# Altium schematic sheet Digital.SchDoc(Digital)
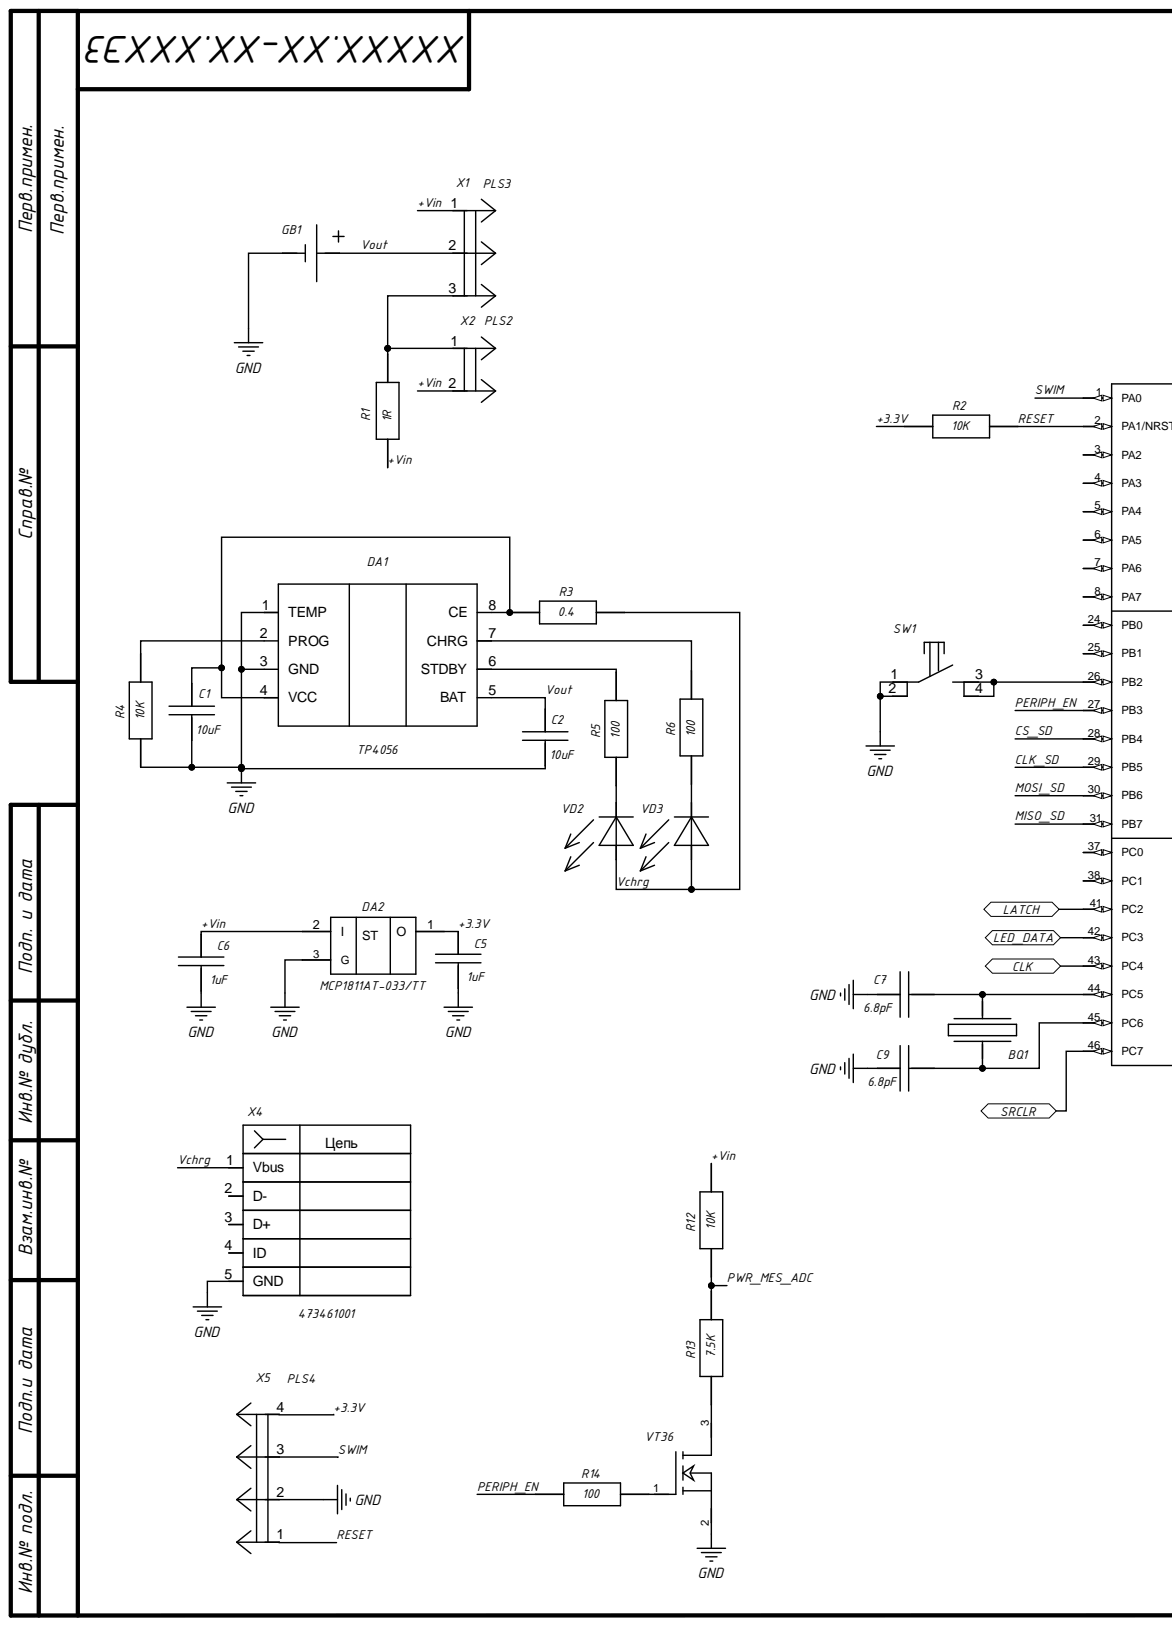
[diagram p0: Digital.SchDoc, left]
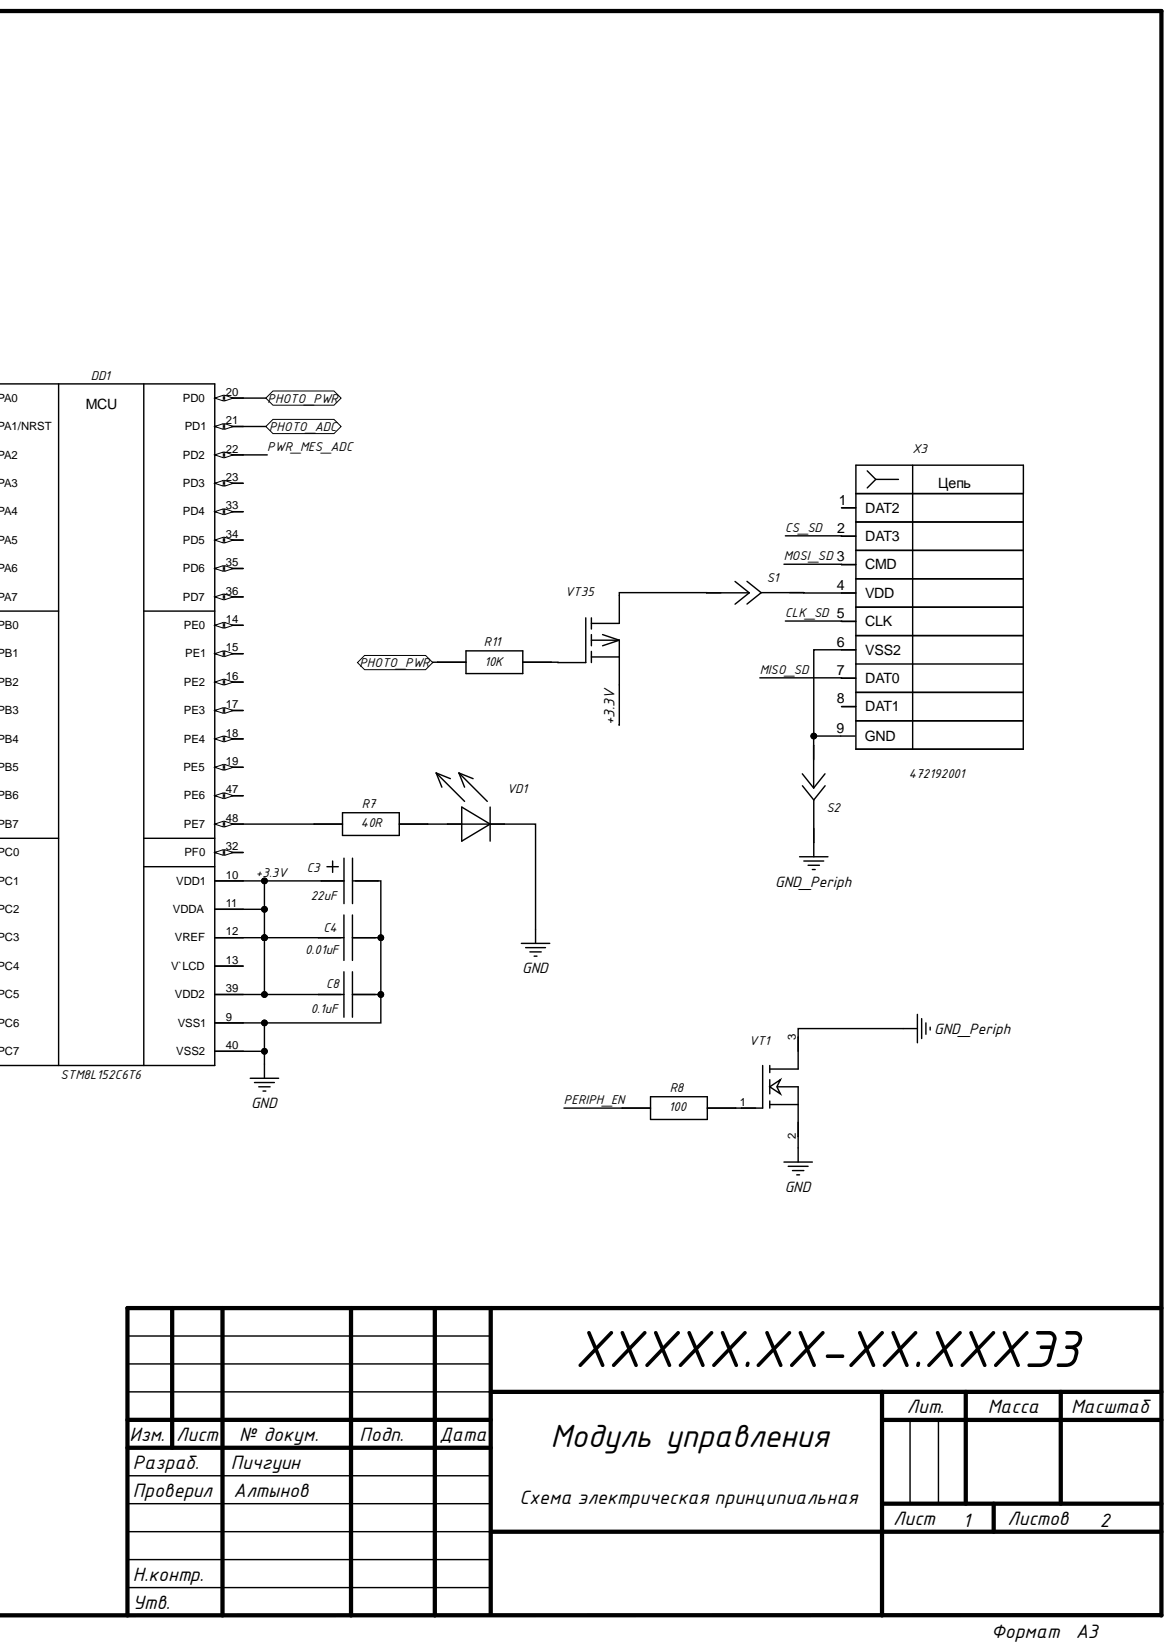
[diagram p1: Digital.SchDoc, right]

## Parts
BQ1  CM315D32768HZFT  [3215SMD]  (value not drawn)
C1  value 10uF  [SMD0603]  (hidden comment: C0603)
C2  value 10uF  [SMD0603]  (hidden comment: C0603)
C3  value 22uF  [AL_5X5.3]  (hidden comment: AL_5X5.3)
C4  value 0.01uF  [SMD0603]  (hidden comment: C0603)
C5  value 1uF  [SMD0603]  (hidden comment: C0603)
C6  value 1uF  [SMD0603]  (hidden comment: C0603)
C7  value 6.8pF  [SMD0603]  (hidden comment: C0603)
C8  value 0.1uF  [SMD0603]  (hidden comment: C0603)
C9  value 6.8pF  [SMD0603]  (hidden comment: C0603)
DA1  TP4056  [SOT-8]
DA2  MCP1811AT-033/TT  [SOT-23(MCP)]
DD1  STM8L152C6T6  [LQFP-48]
GB1  Li-po_102050  [Li-po]  (value not drawn)
R1  value 1R  [SMD1206]  (hidden comment: R1206)
R2  value 10K  [R_SMD0603]  (hidden comment: R0603)
R3  value 0.4  [R_SMD0603]  (hidden comment: R0603)
R4  value 10K  [R_SMD0603]  (hidden comment: R0603)
R5  value 100  [R_SMD0603]  (hidden comment: R0603)
R6  value 100  [R_SMD0603]  (hidden comment: R0603)
R7  value 40R  [R_SMD0603]  (hidden comment: R0603)
R8  value 100  [R_SMD0603]  (hidden comment: R0603)
R11  value 10K  [R_SMD0603]  (hidden comment: R0603)
R12  value 10K  [R_SMD0603]  (hidden comment: R0603)
R13  value 7.5K  [R_SMD0603]  (hidden comment: R0603)
R14  value 100  [R_SMD0603]  (hidden comment: R0603)
S1  Solid_Jumper  [Jumper]  (value not drawn)  (no BOM)
S2  Solid_Jumper  [Jumper]  (value not drawn)  (no BOM)
SW1  KLS7-TS6604-4.3-180  [KLS7-TS6604]  (value not drawn)
VD1  LED0603_Green_3.3V  [SMD0603_L]  (value not drawn)
VD2  LED0603_Green_3.3V  [SMD0603_L]  (value not drawn)
VD3  LED0603_Red_3.3V  [SMD0603_L]  (value not drawn)
VT1  STR2N2VH5  [SOT-23]  (value not drawn)
VT35  IRLML2244TRPBF  [SOT-23]  (value not drawn)
VT36  STR2N2VH5  [SOT-23]  (value not drawn)
X1  PLS3  [PLS3]  (no BOM)
X2  PLS2  [PLS2]  (no BOM)
X3  472192001  [472192001]
X4  473461001  [473461001]
X5  PLS4  [PLS4_NO_BOM]  (no BOM)

## Ports
CLK, LATCH, LED_DATA, PHOTO_ADC, PHOTO_PWR, SRCLR

## Nets
GND: C1.2 C2.2 C3.2 C4.1 C5.2 C6.2 C7.1 C8.1 C9.1 DA1.1(TEMP) DA1.3(GND) DA2.3 DD1.9(VSS1) DD1.40(VSS2) GB1.2 R4.1 SW1.1 SW1.2 VD1.2 VT1.2 VT36.2 X4.5(GND) X5.2
GND_Periph: S2.2 VT1.3
+3.3V: C3.1 C4.2 C5.1 C8.2 DA2.1 DD1.10(VDD1) DD1.11(VDDA) DD1.12(VREF) DD1.39(VDD2) R2.1 VT35.2 X5.4
+Vin: C6.1 DA2.2 R1.1 R12.2 X1.1 X2.2
CLK: DD1.43(PC4) ; port CLK
CLK_SD: DD1.29(PB5) X3.5(CLK)
CS_SD: DD1.28(PB4) X3.2(DAT3)
LATCH: DD1.41(PC2) ; port LATCH
LED_DATA: DD1.42(PC3) ; port LED_DATA
MISO_SD: DD1.31(PB7) X3.7(DAT0)
MOSI_SD: DD1.30(PB6) X3.3(CMD)
PERIPH_EN: DD1.27(PB3) R8.1 R14.1
PHOTO_ADC: DD1.21(PD1) ; port PHOTO_ADC
PHOTO_PWR: DD1.20(PD0) R11.1 ; port PHOTO_PWR
PWR_MES_ADC: DD1.22(PD2) R12.1 R13.2
RESET: DD1.2(PA1/NRST) R2.2 X5.1
SRCLR: DD1.46(PC7) ; port SRCLR
SWIM: DD1.1(PA0) X5.3
Vchrg: R3.2 VD2.1 VD3.1 X4.1(Vbus)
Vout: C2.1 DA1.5(BAT) GB1.1 X1.2
NetBQ1_1: BQ1.1 C9.2 DD1.45(PC6)
NetBQ1_2: BQ1.2 C7.2 DD1.44(PC5)
NetC1_1: C1.1 DA1.4(VCC) R3.1
NetDA1_2: DA1.2(PROG) R4.2
NetDA1_6: DA1.6(STDBY) R5.2
NetDA1_7: DA1.7(CHRG) R6.2
NetDD1_26: DD1.26(PB2) SW1.3 SW1.4
NetDD1_48: DD1.48(PE7) R7.1
NetR1_2: R1.2 X1.3 X2.1
NetR5_1: R5.1 VD2.2
NetR6_1: R6.1 VD3.2
NetR7_2: R7.2 VD1.1
NetR8_2: R8.2 VT1.1
NetR11_2: R11.2 VT35.1
NetR13_1: R13.1 VT36.3
NetR14_2: R14.2 VT36.1
NetS1_1: S1.1 VT35.3
NetS1_2: S1.2 X3.4(VDD)
NetS2_1: S2.1 X3.6(VSS2) X3.9(GND)

Not connected: DA1 8(CE); DD1 3(PA2) 4(PA3) 5(PA4) 6(PA5) 7(PA6) 8(PA7) 13(V`LCD) 14(PE0) 15(PE1) 16(PE2) 17(PE3) 18(PE4) 19(PE5) 23(PD3) 24(PB0) 25(PB1) 32(PF0) 33(PD4) 34(PD5) 35(PD6) 36(PD7) 37(PC0) 38(PC1) 47(PE6); X3 1(DAT2) 8(DAT1); X4 2(D-) 3(D+) 4(ID)

## Library data of the parts
BQ1 = CM315D32768HZFT: ValueManufacturer: Citizen; Component Kind: Standard; Datasheet: https://cfd.citizen.co.jp/english/wp-content/themes/cfd/dist/pdf/datasheet_TF/CM315D_E.pdf; Library Name: quartz.IntLib; ValueManufacturerPartNumber: CM315D32768HZFT
C1 C2 = C0603: ValueManufacturerPartNumber: C0603-X7R-16V-10uF-±10%; Dielectric: X7R; Tolerance: ±10%; ValueVPI: , C0603-X7R-16V-10uF-±10%, (), "" {: }; Voltage: 16V; Component Kind: Standard; Library Name: capacitor.IntLib; ValueSCH: C0603-X7R-16V-10uF-±10%
C3 = AL_5X5.3: Electrolitic capacitor; ValueManufacturerPartNumber: AL_5X5.3-6.3В-22мкФ; Dielectric: {Dielectric}; ValueListComponent: , AL_5X5.3-6.3В-22мкФ, (Electrolitic capacitor), "" {: }; Voltage: 6.3V; Component Kind: Standard; ValueDescription: Electrolitic capacitor; ValueSCH: AL_5X5.3-6.3В-22мкФ; ValueVPI: , AL_5X5.3-6.3В-22мкФ, (Electrolitic capacitor), "" {: }
C4 = C0603: ValueManufacturerPartNumber: C0603-X7R-16V-0.01uF-±10%; Dielectric: X7R; Tolerance: ±10%; ValueVPI: , C0603-X7R-16V-0.01uF-±10%, (), "" {: }; Voltage: 16V; Component Kind: Standard; Library Name: capacitor.IntLib; ValueSCH: C0603-X7R-16V-0.01uF-±10%
C5 C6 = C0603: ValueManufacturerPartNumber: C0603-X7R-16V-1uF-±10%; Dielectric: X7R; Tolerance: ±10%; ValueVPI: , C0603-X7R-16V-1uF-±10%, (), "" {: }; Voltage: 16V; Component Kind: Standard; Library Name: capacitor.IntLib; ValueSCH: C0603-X7R-16V-1uF-±10%
C7 C9 = C0603: ValueManufacturerPartNumber: C0603-X7R-16V-6.8pF-±10%; Dielectric: X7R; Tolerance: ±10%; ValueVPI: , C0603-X7R-16V-6.8pF-±10%, (), "" {: }; Voltage: 16V; Component Kind: Standard; Library Name: capacitor.IntLib; ValueSCH: C0603-X7R-16V-6.8pF-±10%
C8 = C0603: ValueManufacturerPartNumber: C0603-X7R-16V-0.1uF-±10%; Dielectric: X7R; Tolerance: ±10%; ValueVPI: , C0603-X7R-16V-0.1uF-±10%, (), "" {: }; Voltage: 16V; Component Kind: Standard; Library Name: capacitor.IntLib; ValueSCH: C0603-X7R-16V-0.1uF-±10%
DA1 = TP4056: ValueManufacturer: NanJing Top Power ASIC Corp; Component Kind: Standard; Datasheet: https://static.chipdip.ru/lib/977/DOC002977110.pdf; Library Name: power.IntLib; ValueManufacturerPartNumber: TP4056
DA2 = MCP1811AT-033/TT: ValueManufacturer: Microchip; Component Kind: Standard; Datasheet: https://ww1.microchip.com/downloads/en/DeviceDoc/MCP1811A-11B-12A-12B-Family-Data-Sheet-DS20006088C.pdf; Library Name: power.SchLib; ValueManufacturerPartNumber: MCP1811AT-033/TT
DD1 = STM8L152C6T6: ValueManufacturer: STM; Component Kind: Standard; Datasheet: https://www.st.com/resource/en/datasheet/stm8l152c6.pdf; Library Name: mcu.IntLib; ValueManufacturerPartNumber: STM8L152C6T6
GB1 = Li-po_ali: ValueManufacturer: Cmaos; Component Kind: Standard; Datasheet: https://aliexpress.ru/item/1005001863815261.html?spm=a2g0v.12010612.8148356.12.535b6032hQFd15&sku_id=12000017904075781; Description: Li-po_102050; Library Name: battery.IntLib; ValueManufacturerPartNumber: Li-po_102050
R1 = R1206: ValueManufacturerPartNumber: R1206-0.125-1R-±1%; Power: 0.125; Tolerance: ±1%; ValueDescription: Resistor; ValueListComponent: , R1206-0.125-1R-±1%, (Resistor), "" {: }; Component Kind: Standard; Library Name: resistor.IntLib; ValueSCH: R1206-0.125-1R-±1%; ValueVPI: , R1206-0.125-1R-±1%, (Resistor), "" {: }
R2 R4 R11 R12 = R0603: ValueManufacturerPartNumber: R0603-0.1W-10K-±5%; Power: 0.1W; Tolerance: ±5%; ValueDescription: Resistor; ValueListComponent: , R0603-0.1W-10K-±5%, (Resistor), "" {: }; Component Kind: Standard; Library Name: resistor.IntLib; ValueSCH: R0603-0.1W-10K-±5%; ValueVPI: , R0603-0.1W-10K-±5%, (Resistor), "" {: }
R3 = R0603: ValueManufacturerPartNumber: R0603-0.1W-0.4-±5%; Power: 0.1W; Tolerance: ±5%; ValueDescription: Resistor; ValueListComponent: , R0603-0.1W-0.4-±5%, (Resistor), "" {: }; Component Kind: Standard; Library Name: resistor.IntLib; ValueSCH: R0603-0.1W-0.4-±5%; ValueVPI: , R0603-0.1W-0.4-±5%, (Resistor), "" {: }
R5 R6 R8 R14 = R0603: ValueManufacturerPartNumber: R0603-0.1W-100-±5%; Power: 0.1W; Tolerance: ±5%; ValueDescription: Resistor; ValueListComponent: , R0603-0.1W-100-±5%, (Resistor), "" {: }; Component Kind: Standard; Library Name: resistor.IntLib; ValueSCH: R0603-0.1W-100-±5%; ValueVPI: , R0603-0.1W-100-±5%, (Resistor), "" {: }
R7 = R0603: ValueManufacturerPartNumber: R0603-0.1W-40R-±5%; Power: 0.1W; Tolerance: ±5%; ValueDescription: Resistor; ValueListComponent: , R0603-0.1W-40R-±5%, (Resistor), "" {: }; Component Kind: Standard; Library Name: resistor.IntLib; ValueSCH: R0603-0.1W-40R-±5%; ValueVPI: , R0603-0.1W-40R-±5%, (Resistor), "" {: }
R13 = R0603: ValueManufacturerPartNumber: R0603-0.1W-7.5K-±5%; Power: 0.1W; Tolerance: ±5%; ValueDescription: Resistor; ValueListComponent: , R0603-0.1W-7.5K-±5%, (Resistor), "" {: }; Component Kind: Standard; Library Name: resistor.IntLib; ValueSCH: R0603-0.1W-7.5K-±5%; ValueVPI: , R0603-0.1W-7.5K-±5%, (Resistor), "" {: }
SW1 = KLS7-TS6604-4.3-180: ValueManufacturer: KLS; Component Kind: Standard; Datasheet: https://static.chipdip.ru/lib/551/DOC001551970.pdf; Library Name: switch.IntLib; ValueManufacturerPartNumber: KLS7-TS6604-4.3-180
VD1-VD3 = LED0603: Component Kind: Standard; Library Name: led.SchLib
  VD1 VD2: ValueManufacturerPartNumber: LED0603_Green_3.3V
  VD3: ValueManufacturerPartNumber: LED0603_Red_3.3V
VT1 VT36 = STR2N2VH5: ValueManufacturer: STMicroelectronics; ValueListComponent: , STR2N2VH5, (), "STMicroelectronics" {: }; Component Kind: Standard; Library Name: transistor.IntLib; ValueManufacturerPartNumber: STR2N2VH5; ValueVPI: , STR2N2VH5, (), "STMicroelectronics" {: }
VT35 = IRLML2244TRPBF: HEXFET Power MOSFET. P- chanel, Vds=-20, Id=4.3A, Pd=1.3W, Rds=54mΩ(Vgs=-4.5V); ValueManufacturer: Infineon; ValueListComponent: Transistor, IRLML2244TRPBF, (), "Infineon" {: }; ValueType: Transistor; Component Kind: Standard; Datasheet: https://www.infineon.com/dgdl/irlml2244pbf.pdf?fileId=5546d462533600a401535664bfff25f0; Library Name: transistor.IntLib; ValueManufacturerPartNumber: IRLML2244TRPBF; ValueSCH: IRLML2244TRPBF
X3 = 472192001: ValueManufacturer: Molex; Component Kind: Standard; Datasheet: https://www.molex.com/pdm_docs/sd/472192001_sd.pdf; Library Name: connector.IntLib; ValueManufacturerPartNumber: 472192001
X4 = 473461001: ValueManufacturer: Molex; Component Kind: Standard; Datasheet: https://www.molex.com/pdm_docs/sd/473461001_sd.pdf; Library Name: connector.SchLib; ValueManufacturerPartNumber: 473461001
X5 = PLS4_NO_BOM: Library Name: connector.IntLib
S1 S2 = Solid_Jumper: Library Name: connector.SchLib
X1 = PLS3: Library Name: connector.IntLib
X2 = PLS2: Library Name: connector.IntLib
